# Altium schematic sheet GNSSModules.SchDoc
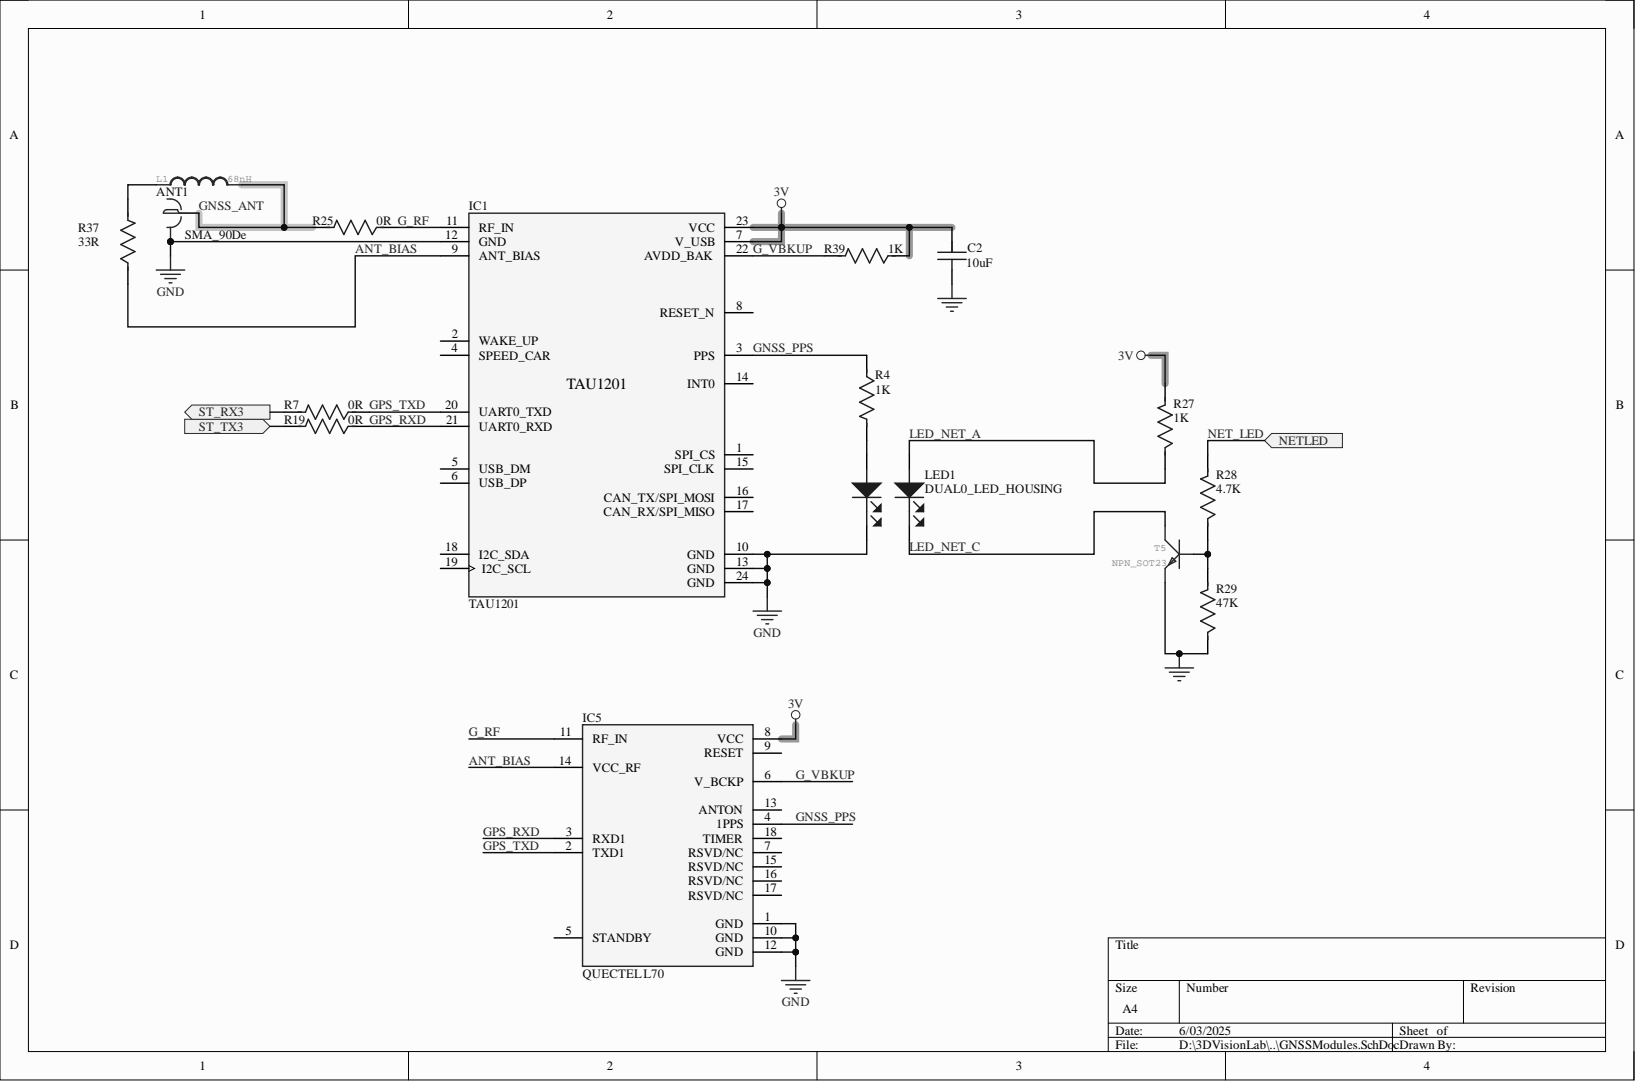
[diagram p0: GNSSModules.SchDoc]

## Parts
ANT1  SMA_90De  [SMA_90De]
C2  10uF  [C0603]
IC1  TAU1201  [GNSS_24PIN]
IC5  QUECTEL L70  MP: L70  [XCVR_L70]
L1  68nH  [C0603]
LED1  DUAL0_LED_HOUSING  [DUAL LED HOUSING]
R4  1K  [R0603]
R7  0R  [R0603]
R19  0R  [R0603]
R25  0R  [R0603]
R27  1K  [R0603]
R28  4.7K  [R0603]
R29  47K  [R0603]
R37  33R  [R0603]
R39  1K  [R0603]
T5  NPN_SOT23  [SOT23]

## Ports
NETLED, ST_RX3, ST_TX3

## Nets
3V: C2.1 IC1.7(V_USB) IC1.23(VCC) IC5.8(VCC) R27.2 R39.1
GND: ANT1.2, 3, 4, 5 C2.2 IC1.10(GND) IC1.12(GND) IC1.13(GND) IC1.24(GND) IC5.1(GND) IC5.10(GND) IC5.12(GND) LED1.2 R29.1 T5.2
ANT_BIAS: IC1.9(ANT_BIAS) IC5.14(VCC_RF) R37.1
G_RF: IC1.11(RF_IN) IC5.11(RF_IN) R25.1
G_VBKUP: IC1.22(AVDD_BAK) IC5.6(V_BCKP) R39.2
GNSS_ANT: ANT1.1 L1.2 R25.2
GNSS_PPS: IC1.3(PPS) IC5.4(1PPS) R4.1
GPS_RXD: IC1.21(UART0_RXD) IC5.3(RXD1) R19.1
GPS_TXD: IC1.20(UART0_TXD) IC5.2(TXD1) R7.1
LED_NET_A: LED1.3 R27.1
LED_NET_C: LED1.4 T5.3
NET_LED: R28.2 ; port NETLED
ST_RX3: R7.2 ; port ST_RX3
ST_TX3: R19.2 ; port ST_TX3
NetIC1_1: IC1.1(SPI_CS)
NetIC1_2: IC1.2(WAKE_UP)
NetIC1_4: IC1.4(SPEED_CAR)
NetIC1_5: IC1.5(USB_DM)
NetIC1_6: IC1.6(USB_DP)
NetIC1_8: IC1.8(RESET_N)
NetIC1_14: IC1.14(INT0)
NetIC1_15: IC1.15(SPI_CLK)
NetIC1_16: IC1.16(CAN_TX/SPI_MOSI)
NetIC1_17: IC1.17(CAN_RX/SPI_MISO)
NetIC1_18: IC1.18(I2C_SDA)
NetIC1_19: IC1.19(I2C_SCL)
NetIC5_5: IC5.5(STANDBY)
NetIC5_7: IC5.7(RSVD/NC)
NetIC5_9: IC5.9(RESET)
NetIC5_13: IC5.13(ANTON)
NetIC5_15: IC5.15(RSVD/NC)
NetIC5_16: IC5.16(RSVD/NC)
NetIC5_17: IC5.17(RSVD/NC)
NetIC5_18: IC5.18(TIMER)
NetL1_1: L1.1 R37.2
NetLED1_1: LED1.1 R4.2
NetR28_1: R28.1 R29.2 T5.1

## Library data of the parts
C2 = Capacitor: Component Kind: Standard; Library Name: UY_Schlib.SchLib
IC1 = TAU1201: Multi-Band Multi-System  GNSS Positioning Module; MF: u-blox; MP: NEO-M9N-00B; Availability: In Stock; Check_prices: https://www.snapeda.com/parts/NEO-M9N-00B/u-blox/view-part/?ref=eda; Component Kind: Standard; Package: SMD-24 u-blox; Price: None; Purchase-URL: https://pricing.snapeda.com/search/part/NEO-M9N-00B/?ref=eda; SnapEDA_Link: https://www.snapeda.com/parts/NEO-M9N-00B/u-blox/view-part/?ref=snap; Library Name: UY_Schlib.SchLib
IC5 = QUECTEL L70: GPS Module <a href="https://pricing.snapeda.com/parts/L70/Quectel/view-part?ref=eda">Check prices</a> <a href="https://pricing.snapeda.com/parts/L70/Quectel/view-part?ref=eda">Check prices</a>; Availability: Unavailable; Component Kind: Standard; Package: None; Price: None; Library Name: UY_Schlib.SchLib
L1 = Inductor 0603: DeviceSetName: L0603; GateName_1: G$1; SymbolName_1: L; Component Kind: Standard; LibraryName: Uy_SMD; Package: 0603; Library Name: UY_Schlib.SchLib
R4 R7 R19 R25 R27-R29 R37 R39 = Resistor: SMD Resistor 0603; Manufacturer: Yageo; Manufacturer Part Number: RC0603FR-071KL; Max Power Dissipation: 100mW; Resistance Tolerance: 1%; Temperature Coefficient: 100ppm/°C; Value: 1kR; Voltage Rating: 50V; Case Code (Imperial): 0603; Case Code (Metric): 1608; Case/Package: 0603; Category: Chip SMD Resistors; Component Kind: Standard; Construction: Rectangular; Contact Plating: Tin,Matte; Depth: 0.8mm; Features: Moisture Resistant; Height: 0.45mm; Lead Free: 5697LeadFree; Length: 1.6mm; Max Operating Temperature: 155°C; Military Standard: Not; Min Operating Temperature: -55°C; Number of Terminations: 2; Packaging: Tape and Reel; Power Rating: 100mW; Pricing: 1=0.1, 10=0.018, 50=0.0106, 100=0.0088, 500=0.00592, 1000=0.00505 (USD); Reach SVHC Compliant: No; Resistor Type: Thick Film; RoHS: Yes; RoHS Compliant: Yes; Series: RC; Stock: 18662657; Supplier: Digi-Key; Supplier Part Number: 311-1.00KHRDKR-ND; Technology: THICK FILM; Termination: SMD/SMT; Tolerance: 1%; Library Name: UY_Schlib.SchLib
T5 = NPN_SOT23: BJT SOT23; DeviceSetName: NPN; GateName_1: G$1; Component Kind: Standard; LibraryName: Uy_SMD; Package: SOT23(1); SymbolName_1: NPN; Library Name: UY_Schlib.SchLib
ANT1 = SMA_90De: Component Kind: Standard; Library Name: UY_Schlib.SchLib
LED1 = DUAL0_LED_HOUSING: Component Kind: Standard; Library Name: UY_Schlib.SchLib
